# Altium schematic sheet VSource.SchDoc
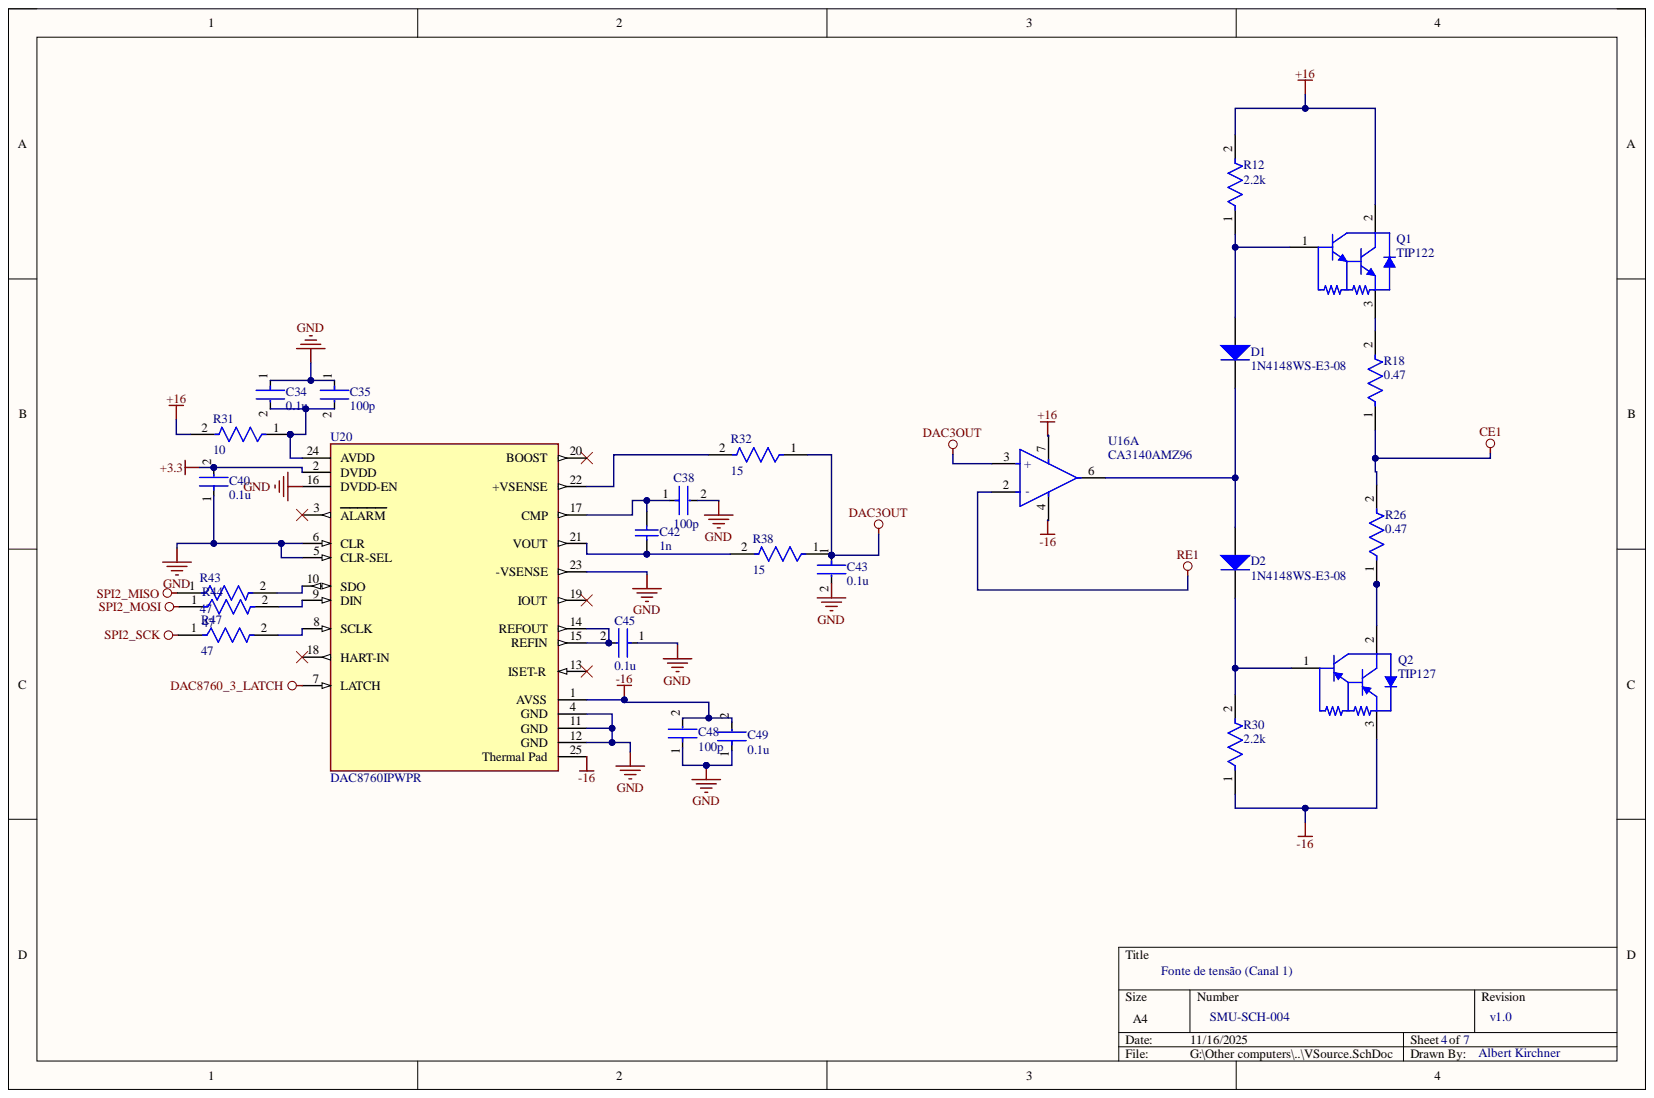
[diagram p0: VSource.SchDoc]

## Parts
C34  0.1u  [FP-CC0805-0_85-MFG]
C35  100p  [FP-0805-L_2_01_0_2-W_1_25-IPC_B]
C38  100p  [FP-0805-L_2_01_0_2-W_1_25-IPC_B]
C40  0.1u  [FP-CC0805-0_85-MFG]
C42  1n  [CAPC2013X70X45ML10T25]
C43  0.1u  [FP-CC0805-0_85-MFG]
C45  0.1u  [FP-CC0805-0_85-MFG]
C48  100p  [FP-0805-L_2_01_0_2-W_1_25-IPC_B]
C49  0.1u  [FP-CC0805-0_85-MFG]
D1  1N4148WS-E3-08  [VISH-SOD-323-2_V]
D2  1N4148WS-E3-08  [VISH-SOD-323-2_V]
Q1  TIP122  [ONSC-TO-220-3-221A-09]
Q2  TIP127  [ONSC-TO-220-3-221A-09]
R12  2.2k  [FP-CRCW1210-e3-IPC_B]
R18  0.47  [FP-CRCW1210-e3-IPC_B]
R26  0.47  [FP-CRCW1210-e3-IPC_B]
R30  2.2k  [FP-CRCW1210-e3-IPC_B]
R31  10  [FP-CR1206-MFG]
R32  15  [FP-CR1206-MFG]
R38  15  [FP-CR1206-MFG]
R43  47  [FP-CR1206-MFG]
R44  47  [FP-CR1206-MFG]
R47  47  [FP-CR1206-MFG]
U16  CA3140AMZ96  [M8_15_MFG]  (part A)
U20  DAC8760IPWPR  [FP-PWP0024J-IPC_A]

## Nets
+3.3: C40.2 U20.2(DVDD)
+16: Q1.2 R12.2 R31.2 U16.7
-16: C48.2 C49.2 Q2.3 R30.1 U16.4 U20.1(AVSS) U20.25(Thermal_Pad)
CE1: R18.1 R26.2
DAC3OUT: C43.1 R32.1 R38.1 U16.3(+)
DAC8760_3_LATCH: U20.7(LATCH)
GND: C34.1 C35.1 C38.2 C40.1 C43.2 C45.1 C48.1 C49.1 U20.4(GND) U20.5(CLR-SEL) U20.6(CLR) U20.11(GND) U20.12(GND) U20.16(DVDD-EN) U20.23(-VSENSE)
RE1: U16.2(-)
SPI2_MISO: R43.1
SPI2_MOSI: R44.1
SPI2_SCK: R47.1
NetC34_2: C34.2 C35.2 R31.1 U20.24(AVDD)
NetC38_1: C38.1 C42.2 U20.17(CMP)
NetC42_1: C42.1 R38.2 U20.21(VOUT)
NetC45_2: C45.2 U20.14(REFOUT) U20.15(REFIN)
NetD1_1: D1.1 Q1.1 R12.1
NetD1_2: D1.2 D2.1 U16.6
NetD2_2: D2.2 Q2.1 R30.2
NetQ1_3: Q1.3 R18.2
NetQ2_2: Q2.2 R26.1
NetR32_2: R32.2 U20.22(+VSENSE)
NetR43_2: R43.2 U20.10(SDO)
NetR44_2: R44.2 U20.9(DIN)
NetR47_2: R47.2 U20.8(SCLK)

Not connected: U20 3(ALARM) 13(ISET-R) 18(HART-IN) 19(IOUT) 20(BOOST)

## Library data of the parts
C34 C40 C43 C45 C49 = CMP-2100-03606-3: CAP CER 0.1UF 50V X7R 0805; Manufacturer: Yageo; Manufacturer Part Number: CC0805KRX7R9BB104; Capacitance: 100 nF; Material: Ceramic; Tolerance: 0.1; Value: 100 nF; Automotive: No; Category: Cap; Component Kind: Standard; ComponentLink2Description: Datasheet; ComponentLink2URL: http://www.yageo.com/documents/recent/UPY-GPHC_Y5V_6.3V-to-50V_8.pdf; Device Class L1: Passive Components; Device Class L2: Capacitors; Device Class L3: Ceramic Capacitors; Digikey Description: CAP CER 0.1UF 50V X7R 0805; Footprint Url: http://www.yageo.com/exep/pages/download/literatures/UPY-C_GEN_24.pdf; Height: 0.95mm; Ipc Land Pattern Name: CAPC200125X85; Lead Free: Yes; Library Name: Altium Content Vault; Mouser Description: Multilayer Ceramic Capacitors MLCC - SMD/SMT 100nF 50V X7R 10%; Octopart: https://octopart.com/cc0805krx7r9bb104-yageo-192993; Package: CC0805-0.85; RoHS: TRUE; Temperature: -30°C to +85°C; Temperature Characteristic: X7R; Temperature Range High: +85°C; Temperature Range Low: -30°C; Voltage Rating: 50 V
C35 C38 C48 = CMP-2007-04119-2: General Purpose Ceramic Capacitor, 0805, 100pF, 1%, C0G, 30ppm/°C, 100V; Capacitance: 100pF; Case Code (Imperial): 0805; Case Code (Metric): 2012; Case/Package: 0805; Component Kind: Standard; Construction: Flat; Depth: 1.25mm; Dielectric: C0G; Dielectric Material: Ceramic; Height: 0.71mm; Lead Free: Lead Free; Length: 2.01mm; Library Name: Altium Content Vault; Max Operating Temperature: 125°C; Min Operating Temperature: -55°C; Mount: Surface Mount; Number of Pins: 2; Package Quantity: 1; Packaging: Tape and Reel; Radiation Hardening: No; REACH SVHC: No SVHC; RoHS Compliant: Yes; Series: -; Termination: SMD/SMT; Thickness: 0.037inch; Tolerance: 1%; Voltage: 100V; Voltage Rating: 100V; Voltage Rating (DC): 100V; Width: 1.25mm
C42 = CMP-2007-00997-1: Manufacturer: Murata; Part Number: GRM216R71H472KA01D; Component Kind: Standard; Library Name: Altium Content Vault
D1 D2 = CMP-2000-05517-2: Small Signal Fast Switching Diode, 1.2 V, 350 mA, -55 to 150 degC, 2-Pin SOD-323, RoHS, Tape and Reel; Manufacturer: Vishay; Brand: VishaySemiconductors; Forward Continuous Current: 150mA; Forward Voltage Drop: 1.2V; Max Surge Current: 350mA; Maximum Diode Capacitance: 4pF; Maximum Power Dissipation: 200mW; Maximum Reverse Leakage Current: 100uA; Package Version: Rev. 5, 09/2009; Peak Reverse Voltage: 100V; Recovery Time: 4ns; Unit Weight: 0.000152oz; Component Kind: Standard; Datasheet URL: http://www.vishay.com/docs/85751/1n4148ws.pdf; Datasheet Version: Rev. 2.0, 05/2013; Factory Pack Quantity: 3000; Library Name: Altium Content Vault; Manufacturer URL: http://www.vishay.com/; Maximum Operating Temperature: +150C; Minimum Operating Temperature: -55C; Mounting Style: SMD/SMT; Mounting Technology: SurfaceMount; Operating Temperature Range: -55Cto+150C; Package / Case: SOD-323; Package Description: 2-Pin Surface Mount Device, Body 1.775 x 1.3 mm, Height 1.15 mm; Package Reference: SOD-323-2; Package URL: http://www.st.com/st-web-ui/static/active/en/resource/technical/document/datasheet/CD00233088.pdf; Packaging: Reel; Product: SwitchingDiodes; Product Category: Diodes-GeneralPurpose,Power,Switching; RoHS: Details; Series: 1N4148WS
Q1 = CMP-1047-00126-1: Plastic Medium-Power, Complementary Silicon Transistor, 3-Pin TO-220, Tube; Manufacturer: On Semiconductor; IC Continuous (A): 5; Polarity: NPN; Component Kind: Standard; ComponentLink1Description: Manufacturer URL; ComponentLink1URL: http://www.onsemi.com/; ComponentLink2Description: Datasheet; ComponentLink2URL: http://www.onsemi.cn/pub_link/Collateral/TIP120-D.PDF; ComponentLink3Description: Package Specification; ComponentLink3URL: http://www.onsemi.com/pub_link/Collateral/221A-09.PDF; DatasheetVersion: Rev. 8; Library Name: Altium Content Vault; Mounting Technology: Through Hole; PackageDescription: 3-Pin Flange Mount, Vertical Straight Lead, Body 9.97 x 4.445 mm, Pitch 2.54 mm; PackageReference: TO-220-3-221A-09; PackageVersion: Rev. AG, 09/2011; Packing: Tube; PartNumber: TIP122; RoHS: FALSE
Q2 = CMP-1031-00026-1: Plastic Medium-Power, Complementary Silicon Transistor, 3-Pin TO-220, Tube; Manufacturer: On Semiconductor; IC Continuous (A): 5; Polarity: PNP; Component Kind: Standard; ComponentLink1Description: Manufacturer URL; ComponentLink1URL: http://www.onsemi.com/; ComponentLink2Description: Datasheet; ComponentLink2URL: http://www.onsemi.cn/pub_link/Collateral/TIP120-D.PDF; ComponentLink3Description: Package Specification; ComponentLink3URL: http://www.onsemi.com/pub_link/Collateral/221A-09.PDF; DatasheetVersion: Rev. 8; Library Name: Altium Content Vault; Mounting Technology: Through Hole; PackageDescription: 3-Pin Flange Mount, Vertical Straight Lead, Body 9.97 x 4.445 mm, Pitch 2.54 mm; PackageReference: TO-220-3-221A-09; PackageVersion: Rev. AG, 09/2011; Packing: Tube; PartNumber: TIP127; RoHS: FALSE
R12 R18 R26 R30 = CMP-2005-01987-2: RES SMD 205K OHM 1% 1/2W 1992; Max Power Dissipation: 500mW; Resistance: 0mR; Temperature Coefficient: 0ppm/°C; Voltage Rating: 200V; Case Code (Imperial): 1210; Case Code (Metric): 3225; Case/Package: 1210; Component Kind: Standard; Composition: Thick Film; Contact Plating: Tin; Depth: 2.5mm; Features: Automotive AEC-Q200; Height: 0.55mm; Length: 3.2mm; Library Name: Altium Content Vault; Max Operating Temperature: 155°C; Military Standard: Not; Min Operating Temperature: -55°C; Mount: Surface Mount; Number of Terminations: 2; Package Quantity: 1; Packaging: Tape and Reel; Power Rating: 500mW; Radiation Hardening: No; REACH SVHC: No SVHC; Termination: SMD/SMT; Weight: 0.000564oz; Width: 0.098inch
R31 R32 R38 R43 R44 R47 = CMP-07231-003158-1: Thick Film Chip Resistors 1206 330Ω 0.25W 1% 100ppm/°C; Max Power Dissipation: 250mW; Resistance: 330R; Voltage Rating: 200V; Case Code (Imperial): 1206; Case Code (Metric): 3216; Case/Package: 1206; Component Kind: Standard; Composition: Thick Film; Contact Plating: Tin; Depth: 1.6mm; Height: 0.6mm; Length: 3.2mm; Library Name: Altium Content Vault; Max Operating Temperature: 155°C; Military Standard: Not; Min Operating Temperature: -55°C; Mount: Surface Mount; Number of Pins: 2; Number of Terminations: 2; Package Quantity: 5000; Packaging: Tape and Reel; Power Rating: 250mW; Radiation Hardening: No; REACH SVHC: No SVHC; Temperature Coefficient: 100ppm/°C; Termination: SMD/SMT; Thickness: 610um; Tolerance: 1%; Voltage Rating (DC): 200V; Width: 1.6mm
U16 = CMP-00572-88253531-2: CA3140 Series 36 V 4.5 MHz BiMOS Operational Amplifier - SOIC-8; Manufacturer Lifecycle Status: ACTIVE; Bandwidth: 4.5MHz; Common Mode Rejection Ratio: 90dB; Dual Supply Voltage: 9V; Gain Bandwidth Product: 3.7MHz; Input Bias Current: 10pA; Input Offset Voltage (Vos): 2mV; Max Dual Supply Voltage: 18V; Max Supply Voltage: 36V; Min Dual Supply Voltage: 2V; Min Supply Voltage: 4V; Nominal Supply Current: 1.6mA; Number of Channels: 1; Operating Supply Current: 4mA; Operating Supply Voltage: 28V; Output Current: 10mA; Output Current per Channel: 40mA; Pitch: 1.3mm; Power Dissipation: 180mW; Power Supply Rejection Ratio (PSRR): 80dB; RAM Size: 640kB; Slew Rate: 9V/µs; Voltage Gain: 100dB; Case/Package: SOIC; Component Kind: Standard; ComponentLink1Description: Manufacturer's Website; ComponentLink1URL: http://www.renesas.com; ComponentLink2Description: Datasheet URL; ComponentLink2URL: https://octopart.com/cad-content/api/get-model-datasheet/15189/88253531; ComponentLink3Description: Manufacturer's Package Drawing; ComponentLink3URL: https://www.renesas.com/en/document/psc/m815-8-lead-narrow-body-small-outline-plastic-package; Contact Plating: Tin; Height: 0m; HelpURL: https://octopart.com/cad-content/api/get-model-datasheet/15189/88253531; Lead Free: Lead Free; Length: 4.9mm; Library Name: Altium Content Vault; Lifecycle Status: Production; Max Operating Temperature: 85°C; Min Operating Temperature: -40°C; Mount: Surface Mount; Number of Circuits: 1; Number of Pins: 8; Radiation Hardening: No; RoHS: Compliant; Schedule B: 8542330000; Width: 3.9mm
U20 = CMP-04861-000014-1: IC DAC 16BIT V OR A-OUT 24HTSSOP; Differential Nonlinearity: 1LSB; Differential Output: No; Interface: SPI; Max Dual Supply Voltage: 18V; Max Power Dissipation: 170mW; Max Supply Voltage: 36V; Min Supply Voltage: 10V; Number of Bits: 16; Number of Channels: 1; Output Type: Voltage; Polarity: Bipolar; Power Consumption: 135mW; Resolution: 16b; Settling Time: 25us; Case/Package: HTSSOP EP; Component Kind: Standard; Contact Plating: Gold; Lead Free: Lead Free; Library Name: Altium Content Vault; Max Operating Temperature: 125°C; Min Operating Temperature: -40°C; Mount: Surface Mount; Number of Converters: 1; Number of D/A Converters: 1; Number of DAC Channels: 1; Number of Pins: 24; Package Quantity: 2000; Packaging: Tape and Reel; REACH SVHC: No SVHC; RoHS Compliant: Yes; Weight: 0.006081oz
